# Altium schematic sheet FTDI.SchDoc(FTDI)
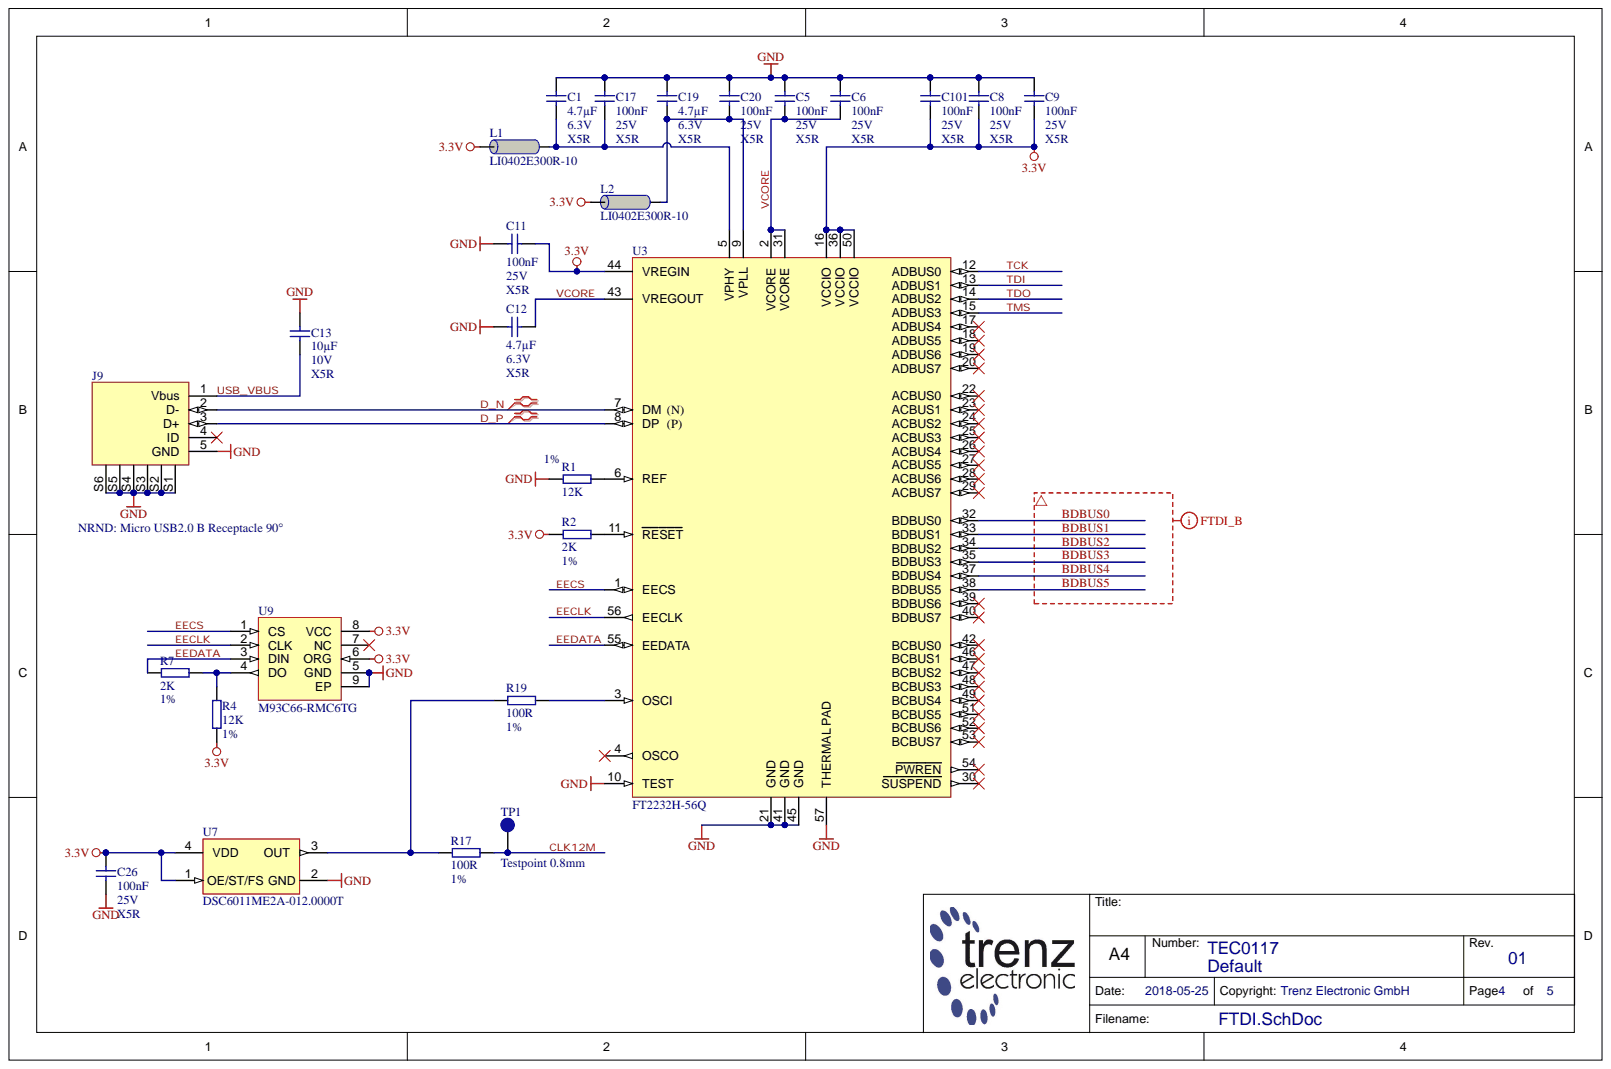
[diagram p0: FTDI.SchDoc]

## Parts
C1  4.7µF  Technology: X5R  Voltage: 6.3V  [CAPC1005X60N]
C5  100nF  Technology: X5R  Voltage: 25V  [CAPC1005X55N]
C6  100nF  Technology: X5R  Voltage: 25V  [CAPC1005X55N]
C8  100nF  Technology: X5R  Voltage: 25V  [CAPC1005X55N]
C9  100nF  Technology: X5R  Voltage: 25V  [CAPC1005X55N]
C11  100nF  Voltage: 25V  [CAPC1005X55N]
C12  4.7µF  Technology: X5R  Voltage: 6.3V  [CAPC1005X60N]
C13  10µF  Technology: X5R  Voltage: 10V  [CAPC2012X135N]
C17  100nF  Technology: X5R  Voltage: 25V  [CAPC1005X55N]
C19  4.7µF  Technology: X5R  Voltage: 6.3V  [CAPC1005X60N]
C20  100nF  Technology: X5R  Voltage: 25V  [CAPC1005X55N]
C26  100nF  Technology: X5R  Voltage: 25V  [CAPC1005X55N]
C101  100nF  Technology: X5R  Voltage: 25V  [CAPC1005X55N]
J9  NRND: Micro USB2.0 B Receptacle 90°  [105017-0001]
L1  LI0402E300R-10  [INDC1005X55N]
L2  LI0402E300R-10  [INDC1005X55N]
R1  12K  Tolerance: 1%  [RESC1005X40N]
R2  2K  Tolerance: 1%  [RESC1005X40N]
R4  12K  Tolerance: 1%  [RESC1005X40N]
R7  2K  Tolerance: 1%  [RESC1005X40N]
R17  100R  Tolerance: 1%  [RESC1005X40N]
R19  100R  Tolerance: 1%  [RESC1005X40N]
TP1  Testpoint 0.8mm  [TP_0.8mm]
U3  FT2232H-56Q  [QFN50P800X800-57T610X610N]
U7  DSC6011ME2A-012.0000T  [2016]
U9  M93C66-RMC6TG  [UFDFPN8]

## Nets
3.3V: C8.1 C9.1 C11.2 C26.2 C101.1 L1.2 L2.2 R2.1 R4.2 U3.16(VCCIO) U3.36(VCCIO) U3.44(VREGIN) U3.50(VCCIO) U7.1(OE/ST/FS) U7.4(VDD) U9.6(ORG) U9.8(VCC)
GND: C1.2 C5.2 C6.2 C8.2 C9.2 C11.1 C12.1 C13.1 C17.2 C19.2 C20.2 C26.1 C101.2 J9.5(GND) J9.S1 J9.S2 J9.S3 J9.S4 J9.S5 J9.S6 R1.1 U3.10(TEST) U3.21(GND) U3.41(GND) U3.45(GND) U3.57(THERMAL_PAD) U7.2(GND) U9.5(GND) U9.9(EP)
BDBUS0: U3.32(BDBUS0)
BDBUS1: U3.33(BDBUS1)
BDBUS2: U3.34(BDBUS2)
BDBUS3: U3.35(BDBUS3)
BDBUS4: U3.37(BDBUS4)
BDBUS5: U3.38(BDBUS5)
CLK12M: R17.2 TP1.1
D_N: J9.2(D-) U3.7(DM)
D_P: J9.3(D+) U3.8(DP)
EECLK: U3.56(EECLK) U9.2(CLK)
EECS: U3.1(EECS) U9.1(CS)
EEDATA: R7.1 U3.55(EEDATA) U9.3(DIN)
TCK: U3.12(ADBUS0)
TDI: U3.13(ADBUS1)
TDO: U3.14(ADBUS2)
TMS: U3.15(ADBUS3)
USB_VBUS: C13.2 J9.1(Vbus)
VCORE: C5.1 C6.1 C12.2 U3.2(VCORE) U3.31(VCORE) U3.43(VREGOUT)
NetC1_1: C1.1 C17.1 L1.1 U3.5(VPHY)
NetC19_1: C19.1 C20.1 L2.1 U3.9(VPLL)
NetR1_2: R1.2 U3.6(REF)
NetR2_2: R2.2 U3.11(RESET)
NetR4_1: R4.1 R7.2 U9.4(DO)
NetR17_1: R17.1 R19.1 U7.3(OUT)
NetR19_2: R19.2 U3.3(OSCI)
N00153:

Not connected: J9 4(ID); U3 4(OSCO) 17(ADBUS4) 18(ADBUS5) 19(ADBUS6) 20(ADBUS7) 22(ACBUS0) 23(ACBUS1) 24(ACBUS2) 25(ACBUS3) 26(ACBUS4) 27(ACBUS5) 28(ACBUS6) 29(ACBUS7) 30(SUSPEND) 39(BDBUS6) 40(BDBUS7) 42(BCBUS0) 46(BCBUS1) 47(BCBUS2) 48(BCBUS3) 49(BCBUS4) 51(BCBUS5) 52(BCBUS6) 53(BCBUS7) 54(PWREN); U9 7(NC)

## Library data of the parts
C1 C12 C19 = 24719: KON 4.7µF 6.3V X5R 20% SMD BF 1005 (0402); Database Table Name: C_1005_0402; Mixed: SMT; Preferred: Yes; Temperature-Range: -55/+85; Value: 4.7u; AN: 24719; Case: 1005 (0402); Component Kind: Standard; Library Name: TE.DbLib; Tolerance: 20%
C5 C6 C8 C9 C11 C17 C20 C26 C101 = 22472: KON 100nF 25V X5R ±10% SMD BF 1005 (0402); Database Table Name: C_1005_0402; Mixed: SMT; Preferred: Yes; Temperature-Range: -55/+85; Value: 100n; AN: 22472; Case: 1005 (0402); Component Kind: Standard; Library Name: TE.DbLib
  C11: Technology: X5R
C13 = 28014: KON 10µF 10V X5R 10% SMD BF 2012 (0805); Database Table Name: C_2012_0805; Mixed: SMT; Temperature-Range: -55/+85; Value: 10u; AN: 28014; Case: 2012 (0805); Component Kind: Standard; Library Name: TE.DbLib; Tolerance: 10%
J9 = 27993: Micro USB2.0 B Receptacle 90° SMD/THT; Database Table Name: CON_IO; Mixed: SMT; AN: 27993; Component Kind: Standard; H-ART: 105017-0001; Library Name: TE.DbLib; HelpURL: B:\ad\adlib\datasheet\105017-0001
L1 L2 = 24681: Ferritdurchführung LI0402E300R-10; Database Table Name: L_1005_0402; Mixed: SMT; Preferred: Yes; Voltage: 500mA; AN: 24681; Case: 1005 (0402); Component Kind: Standard; H-ART: LI0402E300R-10; Library Name: TE.DbLib; Technology: 0.3Ohm; HelpURL: B:\AD\adlib\datasheet\LI0402E300R-10
R1 R4 = 22598: WID SMD 12K 1% 0,063W  BF 1005 (0402); Database Table Name: R_1005_0402; Mixed: SMT; Preferred: Yes; AN: 22598; Case: 1005 (0402); Component Kind: Standard; Library Name: TE.DbLib; Technology: TK100; Value: 12K
R2 R7 = 25073: WID SMD 2K 1% 0,063W  BF 1005 (0402); Database Table Name: R_1005_0402; H-ART: RC0402FR-072KL; Mixed: SMT; Preferred: Yes; AN: 25073; Case: 1005 (0402); Component Kind: Standard; Library Name: TE.DbLib; Technology: TK100; Value: 2K
R17 R19 = 22507: WID SMD 100R 1% 0,063W  BF 1005 (0402); Database Table Name: R_1005_0402; H-ART: RC0402FR-13100RL; Mixed: SMT; Preferred: Yes; Value: 100; AN: 22507; Case: 1005 (0402); Component Kind: Standard; Library Name: TE.DbLib; Technology: TK100
TP1 = 26289: Database Table Name: PCB; Mixed: MECH; AN: 26289; Component Kind: Standard; Description: Testpoint 0.8mm; Library Name: TE.DbLib
U3 = 27277: Database Table Name: IF; Mixed: SMT; Preferred: Yes; Temperature-Range: -40/+85; AN: 27277; Case: VQFN56; Component Kind: Standard; Description: FT2232H-56Q; H-ART: FT2232H-56Q; Library Name: TE.DbLib; Storage-Temperature: -65/+150(Abs); Trenz-Stock: Yes; HelpURL: B:\AD\adlib\datasheet\FT2232H
U7 = 28002: Database Table Name: CLK; Mixed: SMT; Value: 12M; AN: 28002; Case: 2.0 mm x 1.6 mm; Component Kind: Standard; Description: DSC6011ME2A-012.0000T; H-ART: DSC6011ME2A-012.0000T; Library Name: TE.DbLib; HelpURL: b:\AD\adlib\datasheet\MICROCHIP_DSC60XX\
U9 = 27997: Database Table Name: EEPROM; Mixed: SMT; Preferred: Yes; Temperature-Range: -40/+85; AN: 27997; Case: UFDFPN8; Component Kind: Standard; Description: M93C66-RMC6TG; H-ART: M93C66-RMC6TG; Library Name: TE.DbLib; Trenz-Stock: Yes; HelpURL: B:\ad\adlib\datasheet\M93Cx6
